# Altium schematic sheet 05_Ethernet_Memory_Expansion.SchDoc(05_Ethernet_Memory_Expansion)
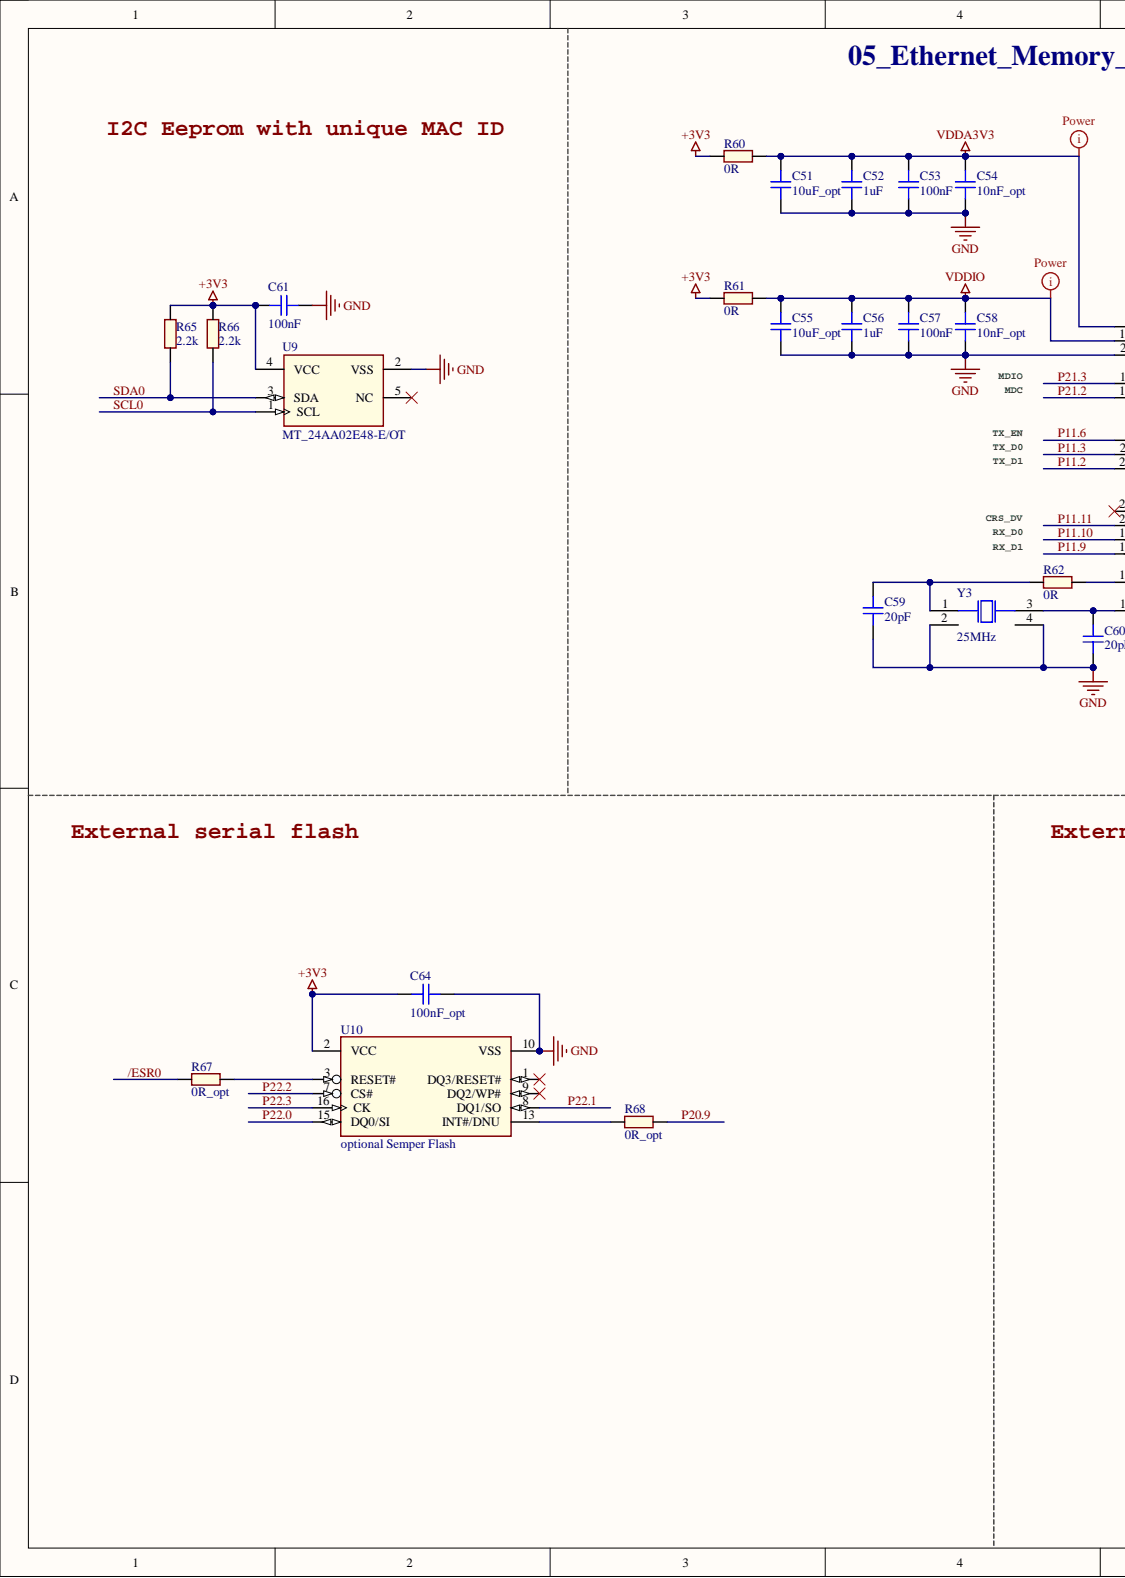
[diagram p0: 05_Ethernet_Memory_Expansion.SchDoc, left]
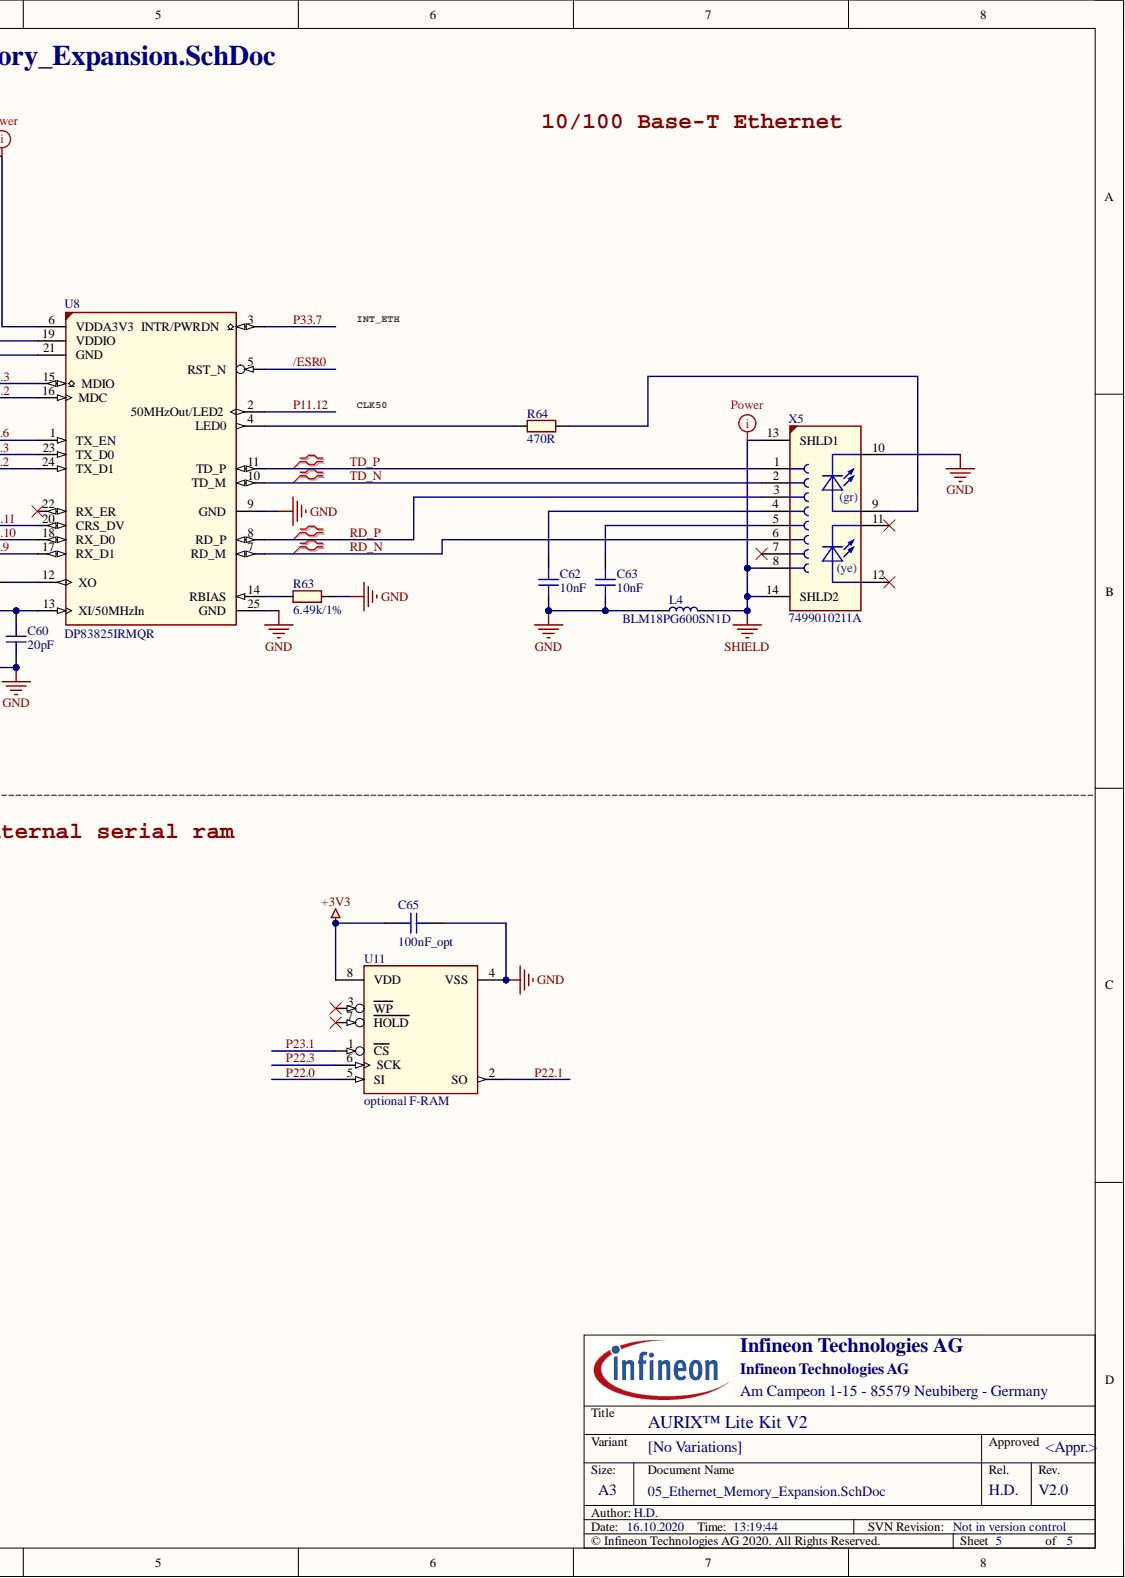
[diagram p1: 05_Ethernet_Memory_Expansion.SchDoc, right]

## Parts
C51  10uF_opt  [CAPC1005X70N]
C52  1uF  [CAPC1005X55N]
C53  100nF  [CAPC1005X55N-1]
C54  10nF_opt  [CAPC1005X55N]
C55  10uF_opt  [CAPC1005X70N]
C56  1uF  [CAPC1005X55N]
C57  100nF  [CAPC1005X55N-1]
C58  10nF_opt  [CAPC1005X55N]
C59  20pF  [CAPC1005X55N-0]
C60  20pF  [CAPC1005X55N-0]
C61  100nF  [CAPC1005X55N-1]
C62  10nF  [CAPC1005X55N]
C63  10nF  [CAPC1005X55N]
C64  100nF_opt  [CAPC1608X90N-4]
C65  100nF_opt  [CAPC1608X90N-4]
L4  BLM18PG600SN1D  [INDC1608X95N-2]
R60  0R  [RESC1609X50N]
R61  0R  [RESC1609X50N]
R62  0R  [RESC1609X50N]
R63  6.49k/1%  [RESC1005X40N]
R64  470R  [RESC1005X40N]
R65  2.2k  [RESC1609X50N]
R66  2.2k  [RESC1609X50N]
R67  0R_opt  [RESC1609X50N]
R68  0R_opt  [RESC1609X50N]
U8  DP83825IRMQR  [QFN40P300X300X80-25N]
U9  MT_24AA02E48-E/OT  [SOT95P270X145-5N-1]
U10  optional Semper Flash  [SOIC127P1030X265-16N-1]
U11  optional F-RAM  [SOIC127P602X173-8N]
X5  7499010211A  [CON-THT-RJ45-7499010211A]
Y3  25MHz  [XTAL-SMD-FA-238]

## Nets
+3V3: C61.1 C64.1 C65.1 R60.1 R61.1 R65.1 R66.1 U9.4(VCC) U10.2(VCC) U11.8(VDD)
GND: C51.1 C52.2 C53.1 C54.2 C55.1 C56.2 C57.2 C58.2 C59.2 C60.2 C61.2 C62.2 C63.2 C64.2 C65.2 L4.1 R63.2 U8.9(GND) U8.21(GND) U8.25(GND) U9.2(VSS) U10.10(VSS) U11.4(VSS) X5.10 Y3.2 Y3.4
SHIELD: L4.2 X5.8 X5.13(SHLD1) X5.14(SHLD2)
VDDA3V3: C51.2 C52.1 C53.2 C54.1 R60.2 U8.6(VDDA3V3)
VDDIO: C55.2 C56.1 C57.1 C58.1 R61.2 U8.19(VDDIO)
/ESR0: R67.2 U8.5(RST_N)
P11.2: U8.24(TX_D1)
P11.3: U8.23(TX_D0)
P11.6: U8.1(TX_EN)
P11.9: U8.17(RX_D1)
P11.10: U8.18(RX_D0)
P11.11: U8.20(CRS_DV)
P11.12: U8.2(50MHzOut/LED2)
P20.9: R68.1
P21.2: U8.16(MDC)
P21.3: U8.15(MDIO)
P22.0: U10.15(DQ0/SI) U11.5(SI)
P22.1: U10.8(DQ1/SO) U11.2(SO)
P22.2: U10.7(CS#)
P22.3: U10.16(CK) U11.6(SCK)
P23.1: U11.1(CS)
P33.7: U8.3(INTR/PWRDN)
RD_N: U8.7(RD_M) X5.6
RD_P: U8.8(RD_P) X5.3
SCL0: R66.2 U9.1(SCL)
SDA0: R65.2 U9.3(SDA)
TD_N: U8.10(TD_M) X5.2
TD_P: U8.11(TD_P) X5.1
NetC59_1: C59.1 R62.1 Y3.1
NetC60_1: C60.1 U8.13(XI/50MHzIn) Y3.3
NetC62_1: C62.1 X5.4
NetC63_1: C63.1 X5.5
NetR62_2: R62.2 U8.12(XO)
NetR63_1: R63.1 U8.14(RBIAS)
NetR64_1: R64.1 U8.4(LED0)
NetR64_2: R64.2 X5.9
NetR67_1: R67.1 U10.3(RESET#)
NetR68_2: R68.2 U10.13(INT#/DNU)

Not connected: U8 22(RX_ER); U9 5(NC); U10 1(DQ3/RESET#) 9(DQ2/WP#); U11 3(WP) 7(HOLD); X5 7 11 12

## Library data of the parts
C51 C55 = 310655: Multi-layer Ceramic Capacitor; Manufacturer: Samsung; Manufacturer Component Status: Active; Manufacturer Order Number: CL05A106MP5NUNC; Alternate View: Yes; Automotive Qualified: No; Combined Parameters: TC:X5R (EIA);Tol.:-20%/+20%;Vdc:10V; Legacy DeviceID: CAP01010925; Package Imperial: 0402; Package Metric: 1005; QuickPick: 10uF / 10V / 20% / X5R (EIA) / 0402; Style: Single; Symbol Type: Capacitor; Single; Standard; 2 Pins; Tolerance Neg: 20%; Type: Standard; Value: 10uF; Version Number: V01; Voltage DC (max): 10V; Article Number: 310655; Component Kind: Standard; ComponentLink1Description: Vendor WebSite; ComponentLink1URL: http://www.samsungsem.co.kr/; ComponentLink2Description: Datasheet; ComponentLink2URL: http://webnetprod.muc.infineon.com/PCBPortal_Prod/WorkFlows/AltiumComponent/StandardDocumentation?Versionid=310656; Legacy PartNumber: CAP01010925; Multiple Parts: No; Package Description: Capacitor,non-polarized,Chip;1.00mm L X 0.50mm W X 0.70mm H; PackageReference: 0402; Part Type: Capacitor; PLMStatus: Active; ROHS Compliant: Y; Second Source allowed: Yes; Supplier 1: Farnell; Supplier 2: DigiKey; Supplier 3: Mouser; Supplier Part Number 2: 1276-1450-2-ND; Supplier Part Number 3: Not Assigned; Technology: Ceramic; Temperature max: 85; Temperature min: -55; Temperature Range: Military; Tolerance Pos: 20%; Library Name: AURIX_Lite_Kit_V2.0.SCHLIB
C52 C56 = 309460: Multilayer Ceramic Chip Capacitor; Manufacturer: Kemet; Manufacturer Order Number: C0402C105K8PACTU; Alternate View: Yes; Automotive Qualified: No; Combined Parameters: TC:X5R (EIA);Tol.:-10%/+10%;Vdc:10V; Legacy DeviceID: CAP01010765; Package Imperial: 0402; Package Metric: 1005; PLMStatus: Active; QuickPick: 1uF / 10V / 10% / X5R (EIA) / 0402 (1005); Style: Single; Symbol Type: Capacitor; Single; Standard; 2 Pins; Tolerance Neg: 10%; Type: Standard; Version Number: V01; Voltage DC (max): 10V; Article Number: 309460; Component Kind: Standard; ComponentLink1Description: Vendor WebSite; ComponentLink1URL: http://www.kemet.com; ComponentLink2Description: Datasheet; ComponentLink2URL: http://webnetprod.muc.infineon.com/PCBPortal_Prod/WorkFlows/AltiumComponent/StandardDocumentation?Versionid=309461; Legacy PartNumber: CAP01010765; Multiple Parts: No; Package Description: Capacitor,non-polarized,Chip;1.00mm L X 0.50mm W X 0.55mm H; PackageReference: 0402 (1005); Part Type: Capacitor; ROHS Compliant: Y; Second Source allowed: Yes; Supplier 1: Farnell; Supplier 2: DigiKey; Supplier 3: Mouser; Supplier Part Number 1: 2392237; Supplier Part Number 2: 399-7765-2-ND; Supplier Part Number 3: 80-C0402C105K8P; Technology: Ceramic; Temperature max: 85; Temperature min: -55; Temperature Range: Military; Tolerance Pos: 10%; Value: 1uF; Library Name: AURIX_Lite_Kit_V2.0.SCHLIB
C53 C57 C61 = 308680: Multilayer Ceramic Chip Capacitor; Manufacturer: TDK Corporation; Manufacturer Component Status: Active; Manufacturer Order Number: CGA2B1X7R1C104K050BC; Multiple Parts: No; Alternate View: Yes; Automotive Qualified: AEC Q200; Combined Parameters: TC:X7R (EIA);Tol.:-10%/+10%;Vdc:16V; Legacy DeviceID: CAP01010669; Package Imperial: 0402; Package Metric: 1005; QuickPick: 100nF / 16V / 10% / X7R (EIA) / 0402 (1005); Style: Single; Symbol Type: Capacitor; Single; Standard; 2 Pins; Tolerance Neg: 10%; Type: Standard; Version Number: V01; Voltage DC (max): 16V; Article Number: 308680; Component Kind: Standard; ComponentLink1Description: Vendor WebSite; ComponentLink1URL: http://www.tdk.com; ComponentLink2Description: Datasheet; ComponentLink2URL: http://webnetprod.muc.infineon.com/PCBPortal_Prod/WorkFlows/AltiumComponent/StandardDocumentation?Versionid=308681; Legacy PartNumber: CAP01010669; Package Description: Capacitor,non-polarized,Chip;1.00mm L X 0.50mm W X 0.55mm H; PackageReference: 0402 (1005); Part Type: Capacitor; PLMStatus: Active; ROHS Compliant: Y; Second Source allowed: Yes; Supplier 1: Farnell; Supplier 2: DigiKey; Supplier 3: Mouser; Supplier Part Number 1: 2458205; Supplier Part Number 2: 445-5613-2-ND; Supplier Part Number 3: 810-CGA2B1X7R1C104K; Technology: Ceramic; Temperature max: 125; Temperature min: -55; Temperature Range: Military; Tolerance Pos: 10%; Value: 100nF; Library Name: AURIX_Lite_Kit_V2.0.SCHLIB
C54 C58 = 308900: Temperature Stable Automotive Grade MLCC Ceramic Capacitor; Manufacturer: Kemet; Manufacturer Order Number: C0402C103K4RACAUTO; Multiple Parts: No; Alternate View: Yes; Automotive Qualified: AEC Q200; Combined Parameters: TC:X7R (EIA);Tol.:-10%/+10%;Vdc:16V; Legacy DeviceID: CAP01010696; Package Imperial: 0402; Package Metric: 1005; PLMStatus: Active; QuickPick: 10nF / 16V / 10% / X7R (EIA) / 0402 (1005); Style: Single; Symbol Type: Capacitor; Single; Standard; 2 Pins; Tolerance Neg: 10%; Type: Standard; Value: 10nF; Version Number: V01; Voltage DC (max): 16V; Article Number: 308900; Component Kind: Standard; ComponentLink1Description: Vendor WebSite; ComponentLink1URL: http://www.kemet.com; ComponentLink2Description: Datasheet; ComponentLink2URL: http://webnetprod.muc.infineon.com/PCBPortal_Prod/WorkFlows/AltiumComponent/StandardDocumentation?Versionid=308901; Legacy PartNumber: CAP01010696; Package Description: Capacitor,non-polarized,Chip;1.00mm L X 0.50mm W X 0.55mm H; PackageReference: 0402 (1005); Part Type: Capacitor; ROHS Compliant: Y; Second Source allowed: Yes; Supplier 1: Farnell; Supplier 2: DigiKey; Supplier 3: Mouser; Supplier Part Number 1: 2478229RL; Supplier Part Number 2: 399-6825-2-ND; Supplier Part Number 3: 80-C0402C103K4RAUTO; Technology: Ceramic; Temperature max: 125; Temperature min: -55; Temperature Range: Military; Tolerance Pos: 10%; Library Name: AURIX_Lite_Kit_V2.0.SCHLIB
C59 C60 = 308044: Chip Monolithic Ceramic Capacitor; Manufacturer: MuRata; Manufacturer Order Number: GRM1555C1H200GA01; Alternate View: Yes; Automotive Qualified: No; Combined Parameters: TC:C0G (EIA) / NP0;Tol.:-2%/+2%;Vdc:50V; Legacy DeviceID: CAP01010598; Package Imperial: 0402; Package Metric: 1005; PLMStatus: Active; QuickPick: 20pF / 50V / 2% / C0G (EIA) / NP0 / 0402; Style: Single; Symbol Type: Capacitor; Single; Standard; 2 Pins; Tolerance Neg: 2%; Type: Standard; Version Number: V01; Voltage DC (max): 50V; Article Number: 308044; Component Kind: Standard; ComponentLink1Description: Vendor WebSite; ComponentLink1URL: http://www.murata.com/; ComponentLink2Description: Datasheet; ComponentLink2URL: http://webnetprod.muc.infineon.com/PCBPortal_Prod/WorkFlows/AltiumComponent/StandardDocumentation?Versionid=308045; Legacy PartNumber: CAP01010598; Multiple Parts: No; Package Description: Capacitor,non-polarized,Chip;1.00mm L X 0.50mm W X 0.55mm H; PackageReference: 0402; Part Type: Capacitor; ROHS Compliant: Y; Second Source allowed: Yes; Supplier 1: Farnell; Supplier 2: DigiKey; Supplier 3: Mouser; Supplier Part Number 2: 490-6217-2-ND; Supplier Part Number 3: 81-GRM1555C1H200GA1D; Technology: Ceramic; Temperature max: 125; Temperature min: -55; Temperature Range: Military; Tolerance Pos: 2%; Value: 20pF; Library Name: AURIX_Lite_Kit_V2.0.SCHLIB
C62 C63 = 308900: Temperature Stable Automotive Grade MLCC Ceramic Capacitor; Manufacturer: Kemet; Manufacturer Order Number: C0402C103K4RACAUTO; Multiple Parts: No; Alternate View: Yes; Automotive Qualified: AEC Q200; Combined Parameters: TC:X7R (EIA);Tol.:-10%/+10%;Vdc:16V; Legacy DeviceID: CAP01010696; Package Imperial: 0402; Package Metric: 1005; PLMStatus: Active; QuickPick: 10nF / 16V / 10% / X7R (EIA) / 0402 (1005); Style: Single; Symbol Type: Capacitor; Single; Standard; 2 Pins; Tolerance Neg: 10%; Type: Standard; Version Number: V01; Voltage DC (max): 16V; Article Number: 308900; Component Kind: Standard; ComponentLink1Description: Vendor WebSite; ComponentLink1URL: http://www.kemet.com; ComponentLink2Description: Datasheet; ComponentLink2URL: http://webnetprod.muc.infineon.com/PCBPortal_Prod/WorkFlows/AltiumComponent/StandardDocumentation?Versionid=308901; Legacy PartNumber: CAP01010696; Package Description: Capacitor,non-polarized,Chip;1.00mm L X 0.50mm W X 0.55mm H; PackageReference: 0402 (1005); Part Type: Capacitor; ROHS Compliant: Y; Second Source allowed: Yes; Supplier 1: Farnell; Supplier 2: DigiKey; Supplier 3: Mouser; Supplier Part Number 1: 2478229RL; Supplier Part Number 2: 399-6825-2-ND; Supplier Part Number 3: 80-C0402C103K4RAUTO; Technology: Ceramic; Temperature max: 125; Temperature min: -55; Temperature Range: Military; Tolerance Pos: 10%; Value: 10nF; Library Name: AURIX_Lite_Kit_V2.0.SCHLIB
C64 C65 = 497792: Multilayer Ceramic Chip Capacitors, Automotive grade, 100 nF, 20%, 100V, 0603; Manufacturer: TDK Corporation; Manufacturer Order Number: CGA3E3X7S2A104M080AB; Multiple Parts: No; Alternate View: Yes; Automotive Qualified: AEC Q200; Combined Parameters: TC:X7S (EIA);Tol.:-20%/+20%;Vdc:100V; Package Imperial: 0603; Package Metric: 1608; PLMStatus: Active; QuickPick: 100nF / 100V / 20% / X7S (EIA) / 0603; Style: Single; Symbol Type: Capacitor; Single; Standard; 2 Pins; Type: Standard; Value: 100nF; Version Number: V01; Application: Standard; Article Number: 497792; Component Kind: Standard; ComponentLink1Description: Vendor WebSite; ComponentLink1URL: http://www.tdk.com; ComponentLink2Description: Datasheet; ComponentLink2URL: http://webnetprod.muc.infineon.com/PCBPortal_Prod/WorkFlows/AltiumComponent/StandardDocumentation?Versionid=497793; Package Description: Capacitor,non-polarized,Chip;1.60mm L X 0.80mm W X 0.90mm H; PackageReference: 0603; Part Type: Capacitor; ROHS Compliant: Y; Second Source allowed: Yes; Supplier 1: Farnell; Supplier 2: DigiKey; Supplier 3: Mouser; Supplier Part Number 1: 2803337; Supplier Part Number 2: 445-12576-1-ND; Supplier Part Number 3: 810-CGA3E3X7S2A104AB; Technology: Ceramic; Temperature max: 125; Temperature min: -55; Temperature Range: Military; Tolerance Neg: 20%; Tolerance Pos: 20%; Voltage DC (max): 100V; Library Name: AURIX_Lite_Kit_V2.0.SCHLIB
L4 = 526189: chip ferrite beads BLM series; Manufacturer: MuRata; Alternate View: Yes; Automotive Qualified: No; Combined Parameters: Tol.:-;Rdc:100mR;Idc:1A;Fres:-; PLMStatus: Active; QuickPick: 60R / Ferrite Bead / 603; Style: Single; Symbol Type: Inductor; Single; Standard; 2 Pins; Value: 60R; Version Number: V01; Voltage DC (max): na; Application: Power Electronics; Article Number: 526189; Component Kind: Standard; ComponentLink1Description: Vendor WebSite; ComponentLink1URL: http://www.murata.com/; ComponentLink2Description: Datasheet; ComponentLink2URL: http://webnetprod.muc.infineon.com/PCBPortal_Prod/WorkFlows/AltiumComponent/StandardDocumentation?Versionid=526190; Manufacturer Order Number: BLM18PG600SN1D; Multiple Parts: No; Package Description: Inductor,Chip;1.60mm L X 0.80mm W X 0.95mm H; PackageReference: 603; Part Type: Inductor; ROHS Compliant: Y; Second Source allowed: Yes; Supplier 1: Farnell; Supplier 2: DigiKey; Supplier 3: Mouser; Supplier Part Number 1: 1515746; Supplier Part Number 2: 490-1036-1-ND; Supplier Part Number 3: 81-BLM11P600S; Temperature max: 125; Temperature min: -55; Temperature Range: Military; Type: Ferrite Bead; Library Name: AURIX_Lite_Kit_V2.0.SCHLIB
R60-R62 = 343882: Standard Thick Film Chip Resistor; Manufacturer: Vishay; Manufacturer Order Number: CRCW06030000Z0; Multiple Parts: No; Alternate View: Yes; Automotive Qualified: AEC Q200; Combined Parameters: TCR:-;Tol.:-0R/+0R;Vdc:75V;Idc:2A;P:-; Legacy DeviceID: RES01800800; PLMStatus: Active; QuickPick: 0R / 0R / 0603; Style: Single; Symbol Type: Resistor; Single; Standard; 2 Pins; Version Number: V01; Voltage DC (max): 75V; Article Number: 343882; Component Kind: Standard; ComponentLink1Description: Vendor WebSite; ComponentLink1URL: http://www.vishay.com; ComponentLink2Description: Datasheet; ComponentLink2URL: http://webnetprod.muc.infineon.com/PCBPortal_Prod/WorkFlows/AltiumComponent/StandardDocumentation?Versionid=343883; Legacy PartNumber: RES01800800; PackageReference: 0603; Part Type: Resistor; ROHS Compliant: Y; Second Source allowed: Yes; Supplier 1: Farnell; Supplier 2: DigiKey; Supplier 3: Mouser; Supplier Part Number 2: 541-0.0GCT-ND; Technology: Thick Film; Temperature max: 155; Temperature min: -55; Temperature Range: Military; Tolerance Neg: 0R; Tolerance Pos: 0R; Type: Standard; Value: 0R; Library Name: AURIX_Lite_Kit_V2.0.SCHLIB
R63 = 342062: Standard Thick Film Chip Resistor; Manufacturer: Vishay; Manufacturer Order Number: CRCW04026K49FK; Multiple Parts: No; Alternate View: Yes; Automotive Qualified: AEC Q200; Combined Parameters: TCR:100ppm/K;Tol.:-1%/+1%;Vdc:50V;Idc:-;P:63mW; Legacy DeviceID: RES01800436; PLMStatus: Active; QuickPick: 6.49k / 1% / 0.0630 / 0402; Style: Single; Symbol Type: Resistor; Single; Standard; 2 Pins; Tolerance Neg: 1%; Value: 6.49k; Version Number: V01; Voltage DC (max): 50V; Article Number: 342062; Component Kind: Standard; ComponentLink1Description: Vendor WebSite; ComponentLink1URL: http://www.vishay.com; ComponentLink2Description: Datasheet; ComponentLink2URL: http://webnetprod.muc.infineon.com/PCBPortal_Prod/WorkFlows/AltiumComponent/StandardDocumentation?Versionid=342063; Legacy PartNumber: RES01800436; PackageReference: 0402; Part Type: Resistor; ROHS Compliant: Y; Second Source allowed: Yes; Supplier 1: Farnell; Supplier 2: DigiKey; Supplier 3: Mouser; Technology: Thick Film; Temperature max: 155; Temperature min: -55; Temperature Range: Military; Tolerance Pos: 1%; Type: Standard; Library Name: AURIX_Lite_Kit_V2.0.SCHLIB
R64 = 341407: Standard Thick Film Chip Resistor; Manufacturer: Vishay; Manufacturer Order Number: CRCW0402470RFK; Multiple Parts: No; Alternate View: Yes; Automotive Qualified: AEC Q200; Combined Parameters: TCR:100ppm/K;Tol.:-1%/+1%;Vdc:50V;Idc:-;P:63mW; Legacy DeviceID: RES01800305; PLMStatus: Active; QuickPick: 470R / 1% / 0.0630 / 0402; Style: Single; Symbol Type: Resistor; Single; Standard; 2 Pins; Tolerance Neg: 1%; Version Number: V01; Voltage DC (max): 50V; Article Number: 341407; Component Kind: Standard; ComponentLink1Description: Vendor WebSite; ComponentLink1URL: http://www.vishay.com; ComponentLink2Description: Datasheet; ComponentLink2URL: http://webnetprod.muc.infineon.com/PCBPortal_Prod/WorkFlows/AltiumComponent/StandardDocumentation?Versionid=341408; Legacy PartNumber: RES01800305; PackageReference: 0402; Part Type: Resistor; ROHS Compliant: Y; Second Source allowed: Yes; Supplier 1: Farnell; Supplier 2: DigiKey; Supplier 3: Mouser; Technology: Thick Film; Temperature max: 155; Temperature min: -55; Temperature Range: Military; Tolerance Pos: 1%; Type: Standard; Value: 470R; Library Name: AURIX_Lite_Kit_V2.0.SCHLIB
R65 R66 = 345782: Standard Thick Film Chip Resistor; Manufacturer: Vishay; Manufacturer Order Number: CRCW06032K20FK; Multiple Parts: No; Alternate View: Yes; Automotive Qualified: AEC Q200; Combined Parameters: TCR:100ppm/K;Tol.:-1%/+1%;Vdc:75V;Idc:-;P:100mW; Legacy DeviceID: RES01801179; PLMStatus: Active; QuickPick: 2.2k / 1% / 0.10 / 0603; Style: Single; Symbol Type: Resistor; Single; Standard; 2 Pins; Tolerance Neg: 1%; Version Number: V01; Voltage DC (max): 75V; Article Number: 345782; Component Kind: Standard; ComponentLink1Description: Vendor WebSite; ComponentLink1URL: http://www.vishay.com; ComponentLink2Description: Datasheet; ComponentLink2URL: http://webnetprod.muc.infineon.com/PCBPortal_Prod/WorkFlows/AltiumComponent/StandardDocumentation?Versionid=345783; Legacy PartNumber: RES01801179; PackageReference: 0603; Part Type: Resistor; ROHS Compliant: Y; Second Source allowed: Yes; Supplier 1: Farnell; Supplier 2: DigiKey; Supplier 3: Mouser; Technology: Thick Film; Temperature max: 155; Temperature min: -55; Temperature Range: Military; Tolerance Pos: 1%; Type: Standard; Value: 2.2k; Library Name: AURIX_Lite_Kit_V2.0.SCHLIB
R67 R68 = 343882: Standard Thick Film Chip Resistor; Manufacturer: Vishay; Manufacturer Order Number: CRCW06030000Z0; Multiple Parts: No; Alternate View: Yes; Automotive Qualified: AEC Q200; Combined Parameters: TCR:-;Tol.:-0R/+0R;Vdc:75V;Idc:2A;P:-; Legacy DeviceID: RES01800800; PLMStatus: Active; QuickPick: 0R / 0R / 0603; Style: Single; Symbol Type: Resistor; Single; Standard; 2 Pins; Tolerance Neg: 0R; Value: 0R; Version Number: V01; Voltage DC (max): 75V; Article Number: 343882; Component Kind: Standard; ComponentLink1Description: Vendor WebSite; ComponentLink1URL: http://www.vishay.com; ComponentLink2Description: Datasheet; ComponentLink2URL: http://webnetprod.muc.infineon.com/PCBPortal_Prod/WorkFlows/AltiumComponent/StandardDocumentation?Versionid=343883; Legacy PartNumber: RES01800800; PackageReference: 0603; Part Type: Resistor; ROHS Compliant: Y; Second Source allowed: Yes; Supplier 1: Farnell; Supplier 2: DigiKey; Supplier 3: Mouser; Supplier Part Number 2: 541-0.0GCT-ND; Technology: Thick Film; Temperature max: 155; Temperature min: -55; Temperature Range: Military; Tolerance Pos: 0R; Type: Standard; Library Name: AURIX_Lite_Kit_V2.0.SCHLIB
U8 = (no symbol name): Low Power 10/100 Mbps Ethernet Physical Layer Transceiver, 10Base-T/100Base-TX PHY with RMII with 25MHz crystal/clock input and 50MHz RMII REF_CLK output, Industrial Temperature; Manufacturer: Texas Instruments; Alternate View: Yes; Article Number: 409425_NEW; Automotive Qualified: No; Combined Parameters: Standard Interface Interface/Transceiver;Vdc min/max:3.14V/3.47V;Iout:-;Fmax:50Hz; Legacy DeviceID: TI_DP83825IRMQR; PLMStatus: Active; QuickPick: WQFN-24; Symbol Type: 10Base-T/100Base-TX PHY with RMII Support; Version Number: V01; Voltage DC (max): na; Application: Standard Interface; Component Kind: Standard; ComponentLink1Description: Vendor WebSite; ComponentLink1URL: http://www.ti.com; ComponentLink2Description: Datasheet; ComponentLink2URL: https://www.ti.com/lit/ds/symlink/dp83825i.pdf; Manufacturer Order Number: DP83825IRMQR; Package Description: WQFN,0.50mm pitch,square;24 pin,3.00mm L X 3.00mm W X 0.80mm H body (w/thermal tab); PackageReference: WQFN-24; Part Type: Integrated Circuit; ROHS Compliant: Y; Second Source allowed: Yes; Supplier 1: Texas Instruments; Supplier 2: DigiKey; Supplier 3: Mouser; Supplier Part Number 1: DP83825IRMQR; Supplier Part Number 2: 296-DP83825IRMQRCT-ND; Supplier Part Number 3: 595-DP83825IRMQR; Temperature max: 85; Temperature min: -40; Temperature Range: Industrial; Type: Interface/Transceiver; Value: DP83825IRMQR; Library Name: AURIX_Lite_Kit_V2.0.SCHLIB
U9 = 448388: 2K I2C Serial EEPROM with EUI-48â„¢ Node Identity; Legacy PartNumber: IC02601202; Manufacturer: Microchip Technology; Alternate View: No; Automotive Qualified: Yes; Combined Parameters: -;-;1I/Os; PLMStatus: Active; QuickPick: 1 / 1.70V - 5.50V / SOT23-5; Version Number: V01; Voltage DC (max): na; Article Number: 448388; Component Kind: Standard; ComponentLink1Description: Vendor WebSite; ComponentLink1URL: http://www.microchip.com/; ComponentLink2Description: Datasheet; ComponentLink2URL: http://webnetprod.muc.infineon.com/PCBPortal_Prod/WorkFlows/AltiumComponent/StandardDocumentation?Versionid=448389; Legacy DeviceID: MT_24AA02E48-E/OT; Manufacturer Order Number: 24AA02E48-E/OT; Multiple Parts: No; Package Description: SOT,0.95mm pitch;5 pin,1.55mm W X 2.90mm L X 1.45mm H body; PackageReference: SOT23-5; Part Type: PLD; ROHS Compliant: Y; Second Source allowed: Yes; Supplier 1: Farnell; Supplier 2: DigiKey; Supplier 3: Mouser; Symbol Type: 2K I2C Serial EEPROM; Temperature max: 125; Temperature min: -40; Temperature Range: Automotive; Value: MT_24AA02E48-E/OT; Library Name: AURIX_Lite_Kit_V2.0.SCHLIB
U10 = 408252_NEW: 512-Mb (16 MB) 3.0 V Semper Flash Memory with Quad SPI; Manufacturer: Cypress Semiconductor; Manufacturer Order Number: S25HL512TDPMHI010; Automotive Qualified: No; Legacy DeviceID: CY_S25HL512TDPMHI010; PLMStatus: Active; Second Source allowed: Yes; Symbol Type: 32-Mbit CMOS 3.0 Volt Flash Memory  with 104-MHz SPI (Serial Peripheral Interface) Multi I/O Bus; Value: S25HL512TDPMHI010; Version Number: V01; Voltage DC (max): na; Article Number: 408252_NEW; Component Kind: Standard; ComponentLink1Description: Vendor WebSite; ComponentLink1URL: http://www.cypress.com; ComponentLink2Description: Datasheet; ComponentLink2URL: https://www.cypress.com/file/506771/download; PackageReference: SO-16; Part Type: Memory; Supplier 1: Mouser; Supplier 2: Digi-Key; Supplier 3: Farnell; Supplier Part Number 1: 727-S25HL512TDPMHI01; Supplier Part Number 2: 428-S25HL512TDPMHI010-ND; Supplier Part Number 3: 3288075; Temperature Range: Military; Library Name: AURIX_Lite_Kit_V2.0.SCHLIB
U11 = 482475_NEW: 4-Mbit (512 K × 8) Serial (SPI) F-RAM, Temp Range (-40°C to +85°C); Manufacturer: Cypress Semiconductor; Manufacturer Order Number: CY15B104Q-S; Alternate View: No; Legacy DeviceID: CYP_CY15B104Q-S; PLMStatus: Active; Second Source allowed: Yes; Value: CY15B104Q-S; Version Number: V01; Voltage DC (max): na; Article Number: 482475_NEW; Component Kind: Standard; ComponentLink1Description: Vendor WebSite; ComponentLink1URL: http://www.cypress.com; ComponentLink2Description: Datasheet; ComponentLink2URL: https://www.cypress.com/file/209146/download; Multiple Parts: No; Package Description: SOIC,1.27mm pitch;8 pin,3.90mm W X 4.89mm L X 1.73mm H body; PackageReference: SOIC-8; Part Type: Memory; ROHS Compliant: Y; Supplier 1: Farnell; Supplier 2: DigiKey; Supplier 3: Mouser; Supplier Part Number 1: 2749232; Supplier Part Number 2: 428-3413-ND; Supplier Part Number 3: 727-CY15B104Q-SXI; Symbol Type: 4-Mbit (512 K × 8) Serial (SPI) F-RAM; Temperature max: 85; Temperature min: -40; Temperature Range: Industrial; Type: Memory Controller; Library Name: AURIX_Lite_Kit_V2.0.SCHLIB
X5 = (no symbol name): RJ45 Connector with Integrated Transformer, 8 positions, 8 contacts, 2 LEDs (green, yellow), Shielded; Legacy PartNumber: CON01500755; Manufacturer: Wurth Elektronik; Alternate View: Yes; Article Number: 384756; Automotive Qualified: No; Combined Parameters: Board-to-Cable Socket (female);-x-;Mounting:THT;Orient:90Â° (right angle);Shielded:Yes; Legacy DeviceID: WE_7499010211A; PLMStatus: Active; QuickPick: 90Â° (right angle) / Board-to-Cable; Style: Western Jack; Version Number: V01; Voltage DC (max): na; Component Kind: Standard; ComponentLink1Description: Vendor WebSite; ComponentLink1URL: http://www.we-online.com; ComponentLink2Description: Datasheet; ComponentLink2URL: http://webnetprod.muc.infineon.com/PCBPortal_Prod/WorkFlows/AltiumComponent/StandardDocumentation?Versionid=384757; Manufacturer Order Number: 7499010211A; Multiple Parts: Yes; Package Description: RJ45 THT Connector, 2.54mm pitch; 15.88mm L X 21.84mm W X 13.50mm H body; Part Type: Connector; ROHS Compliant: Y; Second Source allowed: Yes; Supplier 1: Farnell; Supplier 2: DigiKey; Supplier 3: Mouser; Supplier Part Number 1: 2356127; Supplier Part Number 2: 732-4506-5-ND; Supplier Part Number 3: 710-7499010211A; Symbol Type: RJ45 Connector with integrated transformer, 8 positions, 8 contacts, 2 LEDs (green, yellow), Shielded; Temperature max: 70; Temperature min: 0; Temperature Range: Commercial; Type: Board-to-Cable; Value: 7499010211A; Library Name: AURIX_Lite_Kit_V2.0.SCHLIB
Y3 = 410425: SMD Crystal Unit 25.0000MHz; Legacy PartNumber: IC02600683; Manufacturer: Epson; Manufacturer Order Number: FA-238 25.0000MB-C3; Automotive Qualified: No; Combined Parameters: WLAN Standard Crystal;Tol.:-50%/+50%; Legacy DeviceID: ES_FA-238 25.0000MB-C3; PLMStatus: Active; QuickPick: 25000000 / Crystal; Second Source allowed: Yes; Style: Standard; Symbol type: Standard Crystal Symbol, 4 Pins; Tolerance Neg: 50%; Version Number: V01; Voltage DC (max): na; Application: WLAN; Article Number: 410425; Component Kind: Standard; ComponentLink1Description: Vendor WebSite; ComponentLink1URL: http://www.epsondevice.com/; ComponentLink2Description: Datasheet; ComponentLink2URL: http://webnetprod.muc.infineon.com/PCBPortal_Prod/WorkFlows/AltiumComponent/StandardDocumentation?Versionid=410426; Package Description: Crystal; 3.2mm W X 2.5mm L X 0.7mm H body; PackageReference: SMD 3.2 x 2.5 x 0.7mm; Part Type: Clock; ROHS Compliant: Y; Supplier 1: Farnell; Supplier 2: DigiKey; Supplier 3: Mouser; Supplier Part Number 2: SER3691TR-ND; Supplier Part Number 3: 732-FA238-25B-C3; Temperature max: 85; Temperature min: -40; Temperature Range: Industrial; Tolerance Pos: 50%; Type: Crystal; Value: 25MHz; Library Name: AURIX_Lite_Kit_V2.0.SCHLIB
